# Altium schematic sheet P07_Exp Conn, LCD Conn.SchDoc
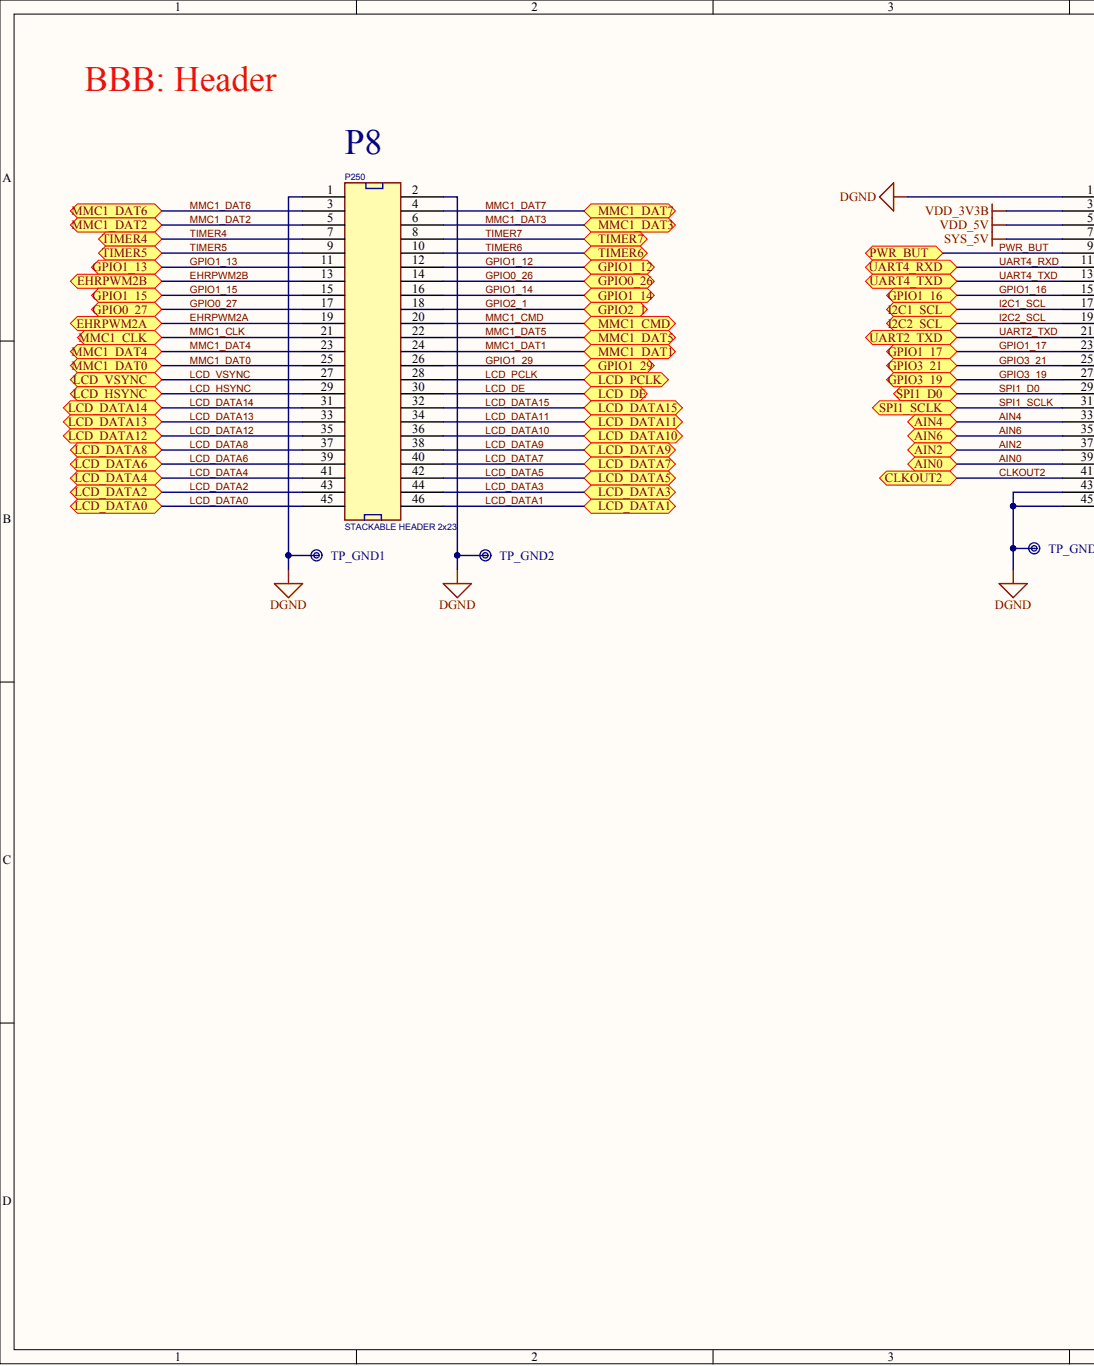
[diagram p0: P07_Exp Conn, LCD Conn.SchDoc, left]
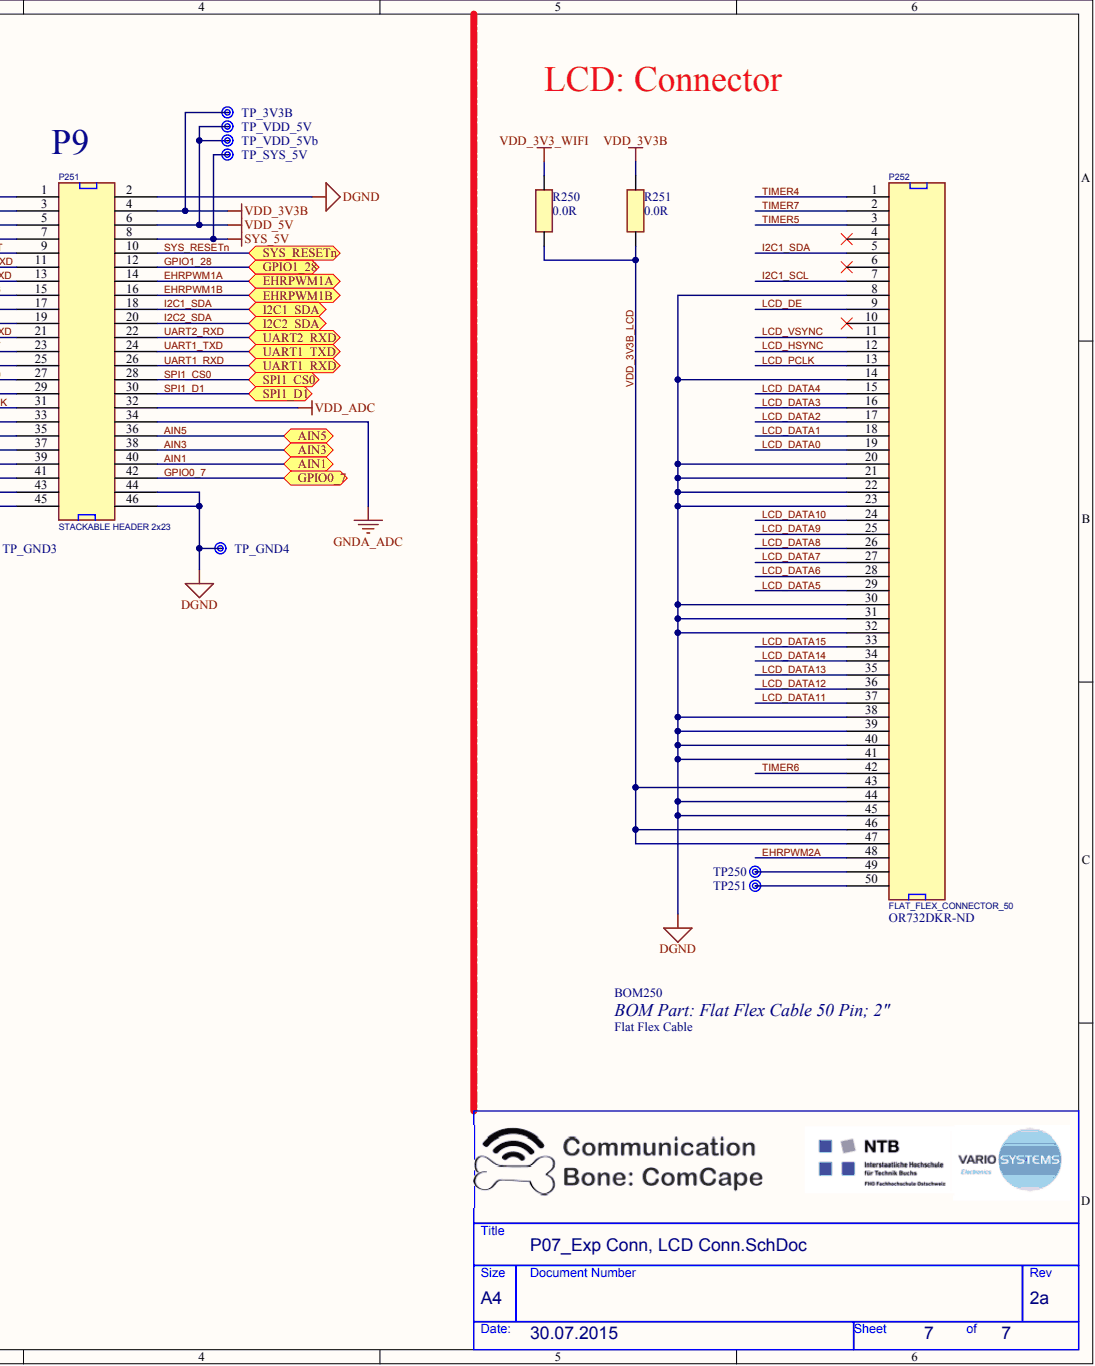
[diagram p1: P07_Exp Conn, LCD Conn.SchDoc, right]

## Parts
BOM250  Flat Flex Cable  (mechanical)
P250  STACKABLE HEADER 2x23  [HEADER23X2_SMD]
P251  STACKABLE HEADER 2x23  [HEADER23X2]
P252  FLAT_FLEX_CONNECTOR_50  Supplier Part Number 1: OR732DKR-ND  [FlatFlex_CONNECTOR_50_XF2M]
R250  0.0R  [SM/R_0603]
R251  0.0R  [SM/R_0603]
TP250  Testpoint mit Bohrung  [TP_mit_0.7mm_Bohrung]  (value not drawn)  (no BOM)
TP251  Testpoint mit Bohrung  [TP_mit_0.7mm_Bohrung]  (value not drawn)  (no BOM)
TP_3V3B  Testpoint mit Bohrung  [TP_mit_0.7mm_Bohrung]  (value not drawn)  (no BOM)
TP_GND1  Testpoint mit Bohrung  [TP_mit_0.7mm_Bohrung]  (value not drawn)  (no BOM)
TP_GND2  Testpoint mit Bohrung  [TP_mit_0.7mm_Bohrung]  (value not drawn)  (no BOM)
TP_GND3  Testpoint mit Bohrung  [TP_mit_0.7mm_Bohrung]  (value not drawn)  (no BOM)
TP_GND4  Testpoint mit Bohrung  [TP_mit_0.7mm_Bohrung]  (value not drawn)  (no BOM)
TP_SYS_5V  Testpoint mit Bohrung  [TP_mit_0.7mm_Bohrung]  (value not drawn)  (no BOM)
TP_VDD_5V  Testpoint mit Bohrung  [TP_mit_0.7mm_Bohrung]  (value not drawn)  (no BOM)
TP_VDD_5Vb  Testpoint mit Bohrung  [TP_mit_0.7mm_Bohrung]  (value not drawn)  (no BOM)

## Ports
AIN0, AIN1, AIN2, AIN3, AIN4, AIN5, AIN6, CLKOUT2, EHRPWM1A, EHRPWM1B, EHRPWM2A, EHRPWM2B, GPIO0_7, GPIO0_26, GPIO0_27, GPIO1_12, GPIO1_13, GPIO1_14, GPIO1_15, GPIO1_16, GPIO1_17, GPIO1_28, GPIO1_29, GPIO2_1, GPIO3_19, GPIO3_21, I2C1_SCL, I2C1_SDA, I2C2_SCL, I2C2_SDA, LCD_DATA0, LCD_DATA1, LCD_DATA2, LCD_DATA3, LCD_DATA4, LCD_DATA5, LCD_DATA6, LCD_DATA7, LCD_DATA8, LCD_DATA9, LCD_DATA10, LCD_DATA11, LCD_DATA12, LCD_DATA13, LCD_DATA14, LCD_DATA15, LCD_DE, LCD_HSYNC, LCD_PCLK, LCD_VSYNC, MMC1_CLK, MMC1_CMD, MMC1_DAT0, MMC1_DAT1, MMC1_DAT2, MMC1_DAT3, MMC1_DAT4, MMC1_DAT5, MMC1_DAT6, MMC1_DAT7, PWR_BUT, SPI1_CS0, SPI1_D0, SPI1_D1, SPI1_SCLK, SYS_RESETN, TIMER4, TIMER5, TIMER6, TIMER7, UART1_RXD, UART1_TXD, UART2_RXD, UART2_TXD, UART4_RXD, UART4_TXD

## Nets
DGND: P250.1 P250.2 P251.1 P251.2 P251.43 P251.44 P251.45 P251.46 P252.8 P252.14 P252.20 P252.21 P252.22 P252.23 P252.30 P252.31 P252.32 P252.38 P252.39 P252.40 P252.41 P252.44 P252.45 TP_GND1.1 TP_GND2.1 TP_GND3.1 TP_GND4.1
GNDA_ADC: P251.34
SYS_5V: P251.7 P251.8 TP_SYS_5V.1
VDD_3V3_WIFI: R250.2
VDD_3V3B: P251.3 P251.4 R251.2 TP_3V3B.1
VDD_5V: P251.5 P251.6 TP_VDD_5V.1 TP_VDD_5Vb.1
VDD_ADC: P251.32
AIN0: P251.39 ; port AIN0
AIN1: P251.40 ; port AIN1
AIN2: P251.37 ; port AIN2
AIN3: P251.38 ; port AIN3
AIN4: P251.33 ; port AIN4
AIN5: P251.36 ; port AIN5
AIN6: P251.35 ; port AIN6
CLKOUT2: P251.41 ; port CLKOUT2
EHRPWM1A: P251.14 ; port EHRPWM1A
EHRPWM1B: P251.16 ; port EHRPWM1B
EHRPWM2A: P250.19 P252.48 ; port EHRPWM2A
EHRPWM2B: P250.13 ; port EHRPWM2B
GPIO0_7: P251.42 ; port GPIO0_7
GPIO0_26: P250.14 ; port GPIO0_26
GPIO0_27: P250.17 ; port GPIO0_27
GPIO1_12: P250.12 ; port GPIO1_12
GPIO1_13: P250.11 ; port GPIO1_13
GPIO1_14: P250.16 ; port GPIO1_14
GPIO1_15: P250.15 ; port GPIO1_15
GPIO1_16: P251.15 ; port GPIO1_16
GPIO1_17: P251.23 ; port GPIO1_17
GPIO1_28: P251.12 ; port GPIO1_28
GPIO1_29: P250.26 ; port GPIO1_29
GPIO2_1: P250.18 ; port GPIO2_1
GPIO3_19: P251.27 ; port GPIO3_19
GPIO3_21: P251.25 ; port GPIO3_21
I2C1_SCL: P251.17 P252.7 ; port I2C1_SCL
I2C1_SDA: P251.18 P252.5 ; port I2C1_SDA
I2C2_SCL: P251.19 ; port I2C2_SCL
I2C2_SDA: P251.20 ; port I2C2_SDA
LCD_DATA0: P250.45 P252.19 ; port LCD_DATA0
LCD_DATA1: P250.46 P252.18 ; port LCD_DATA1
LCD_DATA2: P250.43 P252.17 ; port LCD_DATA2
LCD_DATA3: P250.44 P252.16 ; port LCD_DATA3
LCD_DATA4: P250.41 P252.15 ; port LCD_DATA4
LCD_DATA5: P250.42 P252.29 ; port LCD_DATA5
LCD_DATA6: P250.39 P252.28 ; port LCD_DATA6
LCD_DATA7: P250.40 P252.27 ; port LCD_DATA7
LCD_DATA8: P250.37 P252.26 ; port LCD_DATA8
LCD_DATA9: P250.38 P252.25 ; port LCD_DATA9
LCD_DATA10: P250.36 P252.24 ; port LCD_DATA10
LCD_DATA11: P250.34 P252.37 ; port LCD_DATA11
LCD_DATA12: P250.35 P252.36 ; port LCD_DATA12
LCD_DATA13: P250.33 P252.35 ; port LCD_DATA13
LCD_DATA14: P250.31 P252.34 ; port LCD_DATA14
LCD_DATA15: P250.32 P252.33 ; port LCD_DATA15
LCD_DE: P250.30 P252.9 ; port LCD_DE
LCD_HSYNC: P250.29 P252.12 ; port LCD_HSYNC
LCD_PCLK: P250.28 P252.13 ; port LCD_PCLK
LCD_VSYNC: P250.27 P252.11 ; port LCD_VSYNC
MMC1_CLK: P250.21 ; port MMC1_CLK
MMC1_CMD: P250.20 ; port MMC1_CMD
MMC1_DAT0: P250.25 ; port MMC1_DAT0
MMC1_DAT1: P250.24 ; port MMC1_DAT1
MMC1_DAT2: P250.5 ; port MMC1_DAT2
MMC1_DAT3: P250.6 ; port MMC1_DAT3
MMC1_DAT4: P250.23 ; port MMC1_DAT4
MMC1_DAT5: P250.22 ; port MMC1_DAT5
MMC1_DAT6: P250.3 ; port MMC1_DAT6
MMC1_DAT7: P250.4 ; port MMC1_DAT7
PWR_BUT: P251.9 ; port PWR_BUT
SPI1_CS0: P251.28 ; port SPI1_CS0
SPI1_D0: P251.29 ; port SPI1_D0
SPI1_D1: P251.30 ; port SPI1_D1
SPI1_SCLK: P251.31 ; port SPI1_SCLK
SYS_RESETN: P251.10 ; port SYS_RESETN
TIMER4: P250.7 P252.1 ; port TIMER4
TIMER5: P250.9 P252.3 ; port TIMER5
TIMER6: P250.10 P252.42 ; port TIMER6
TIMER7: P250.8 P252.2 ; port TIMER7
UART1_RXD: P251.26 ; port UART1_RXD
UART1_TXD: P251.24 ; port UART1_TXD
UART2_RXD: P251.22 ; port UART2_RXD
UART2_TXD: P251.21 ; port UART2_TXD
UART4_RXD: P251.11 ; port UART4_RXD
UART4_TXD: P251.13 ; port UART4_TXD
VDD_3V3B_LCD: P252.43 P252.46 P252.47 R250.1 R251.1
NetP252_49: P252.49 TP250.1
NetP252_50: P252.50 TP251.1

Not connected: P252 4 6 10

## Library data of the parts
P250 = HDR_46_XX_2_0.1_0.1_SMD: CONN HEADER STACKABLE  46PS.1" DL GOLD SMD; Number of Positions: 46; Number of Positions Loaded: All; Pitch: 0.100" (2.54mm); Category: Connectors, Interconnects; Component Kind: Standard; Mounting Type: SMD; Number of Rows: 2; RoHS: RoHS Compliant; Row Spacing: 0.100" (2.54mm); Supplier 1: Digi-Key; Supplier Part Number 1: S2351E-23-ND; Termination: Solder; Library Name: ComCape.SchLib
P251 = HDR_46_XX_2_0.1_0.1: CONN HEADER STACKABLE  46PS.1" DL GOLD; Manufacturer: xx-Sullins Connector Solutions; Number of Positions: 46; Number of Positions Loaded: All; Pitch: 0.100" (2.54mm); Category: Connectors, Interconnects; Color: Black; Component Kind: Standard; ComponentLink1Description: http://www.sullinscorp.com/drawings/78_P(N)PxCxxxLFBN-RC,_10492-G.pdf; ComponentLink1URL: http://www.sullinscorp.com/drawings/78_P(N)PxCxxxLFBN-RC,_10492-G.pdf; Connector Type: Header; Contact Finish: Gold; Contact Finish Thickness: Flash; Contact Type: Female Socket; Fastening Type: -; Features: -; Mounting Type: Through Hole; Number of Rows: 2; Packaging: Bulk; RoHS: RoHS Compliant; Row Spacing: 0.100" (2.54mm); Supplier 1: Digi-Key; Supplier Part Number 1: S2011EC-23-ND; Termination: Solder; Library Name: ComCape.SchLib
P252 = FLAT_FLEX_CONNECTOR_50: CONN FPC 50POS 0.50MM R/A; Manufacturer: Omron Electronics Inc-EMC Div; Manufacturer Part Number: XF2M-5015-1A; Number of Positions: 50; Number of Positions Loaded: All; Pitch: 0.500mm; Category: Connectors, Interconnects; Color: White; Component Kind: Standard; ComponentLink1Description: http://www.te.com/commerce/DocumentDelivery/DDEController?Action=srchrtrv&DocNm=1734839&DocType=Customer+Drawing&DocLang=English; ComponentLink1URL: http://www.te.com/commerce/DocumentDelivery/DDEController?Action=srchrtrv&DocNm=1734839&DocType=Customer+Drawing&DocLang=English; Contact Finish: Gold; Contact Type: Female Socket; Flat Flex Type: FPC; Mounting Type: SMT; Number of Rows: 1; RoHS: RoHS Compliant; Row Spacing: 0.500mm; Supplier 1: Digi-Key; Termination: Solder; Library Name: ComCape.SchLib
R250 R251 = SC RES __0603 __0R00 __0W1 _____ T100 __: Manufacturer: Generic Component; Part Number: 206748; Cust: Y; HTNr: take notice of key data; Power: 0.1W; Std: STD SET; Tolerance: 1%; Component Kind: Standard; Description: SC RES __0603 __0R00 __0W1 _____ T100 __; Part Type: RES-SMD\0603; PCB Footprint: SM/R_0603; Supplier 1: Digi-Key; Supplier Part Number 1: 1276-3510-1-ND; Value: 0.0R; Library Name: ComCape.SchLib
TP250 TP251 TP_3V3B TP_GND1-TP_GND4 TP_SYS_5V TP_VDD_5V TP_VDD_5Vb = Testpoint mit Bohrung: TP_0.7mm_THRU; Library Name: ComCape.SchLib
BOM250 = Flat Flex Cable: Supplier 1: Digi-Key; Supplier Part Number 1: HFF-50U-02-ND; Library Name: ComCape.SchLib
